# Altium schematic sheet Drive_A2V3.SchDoc
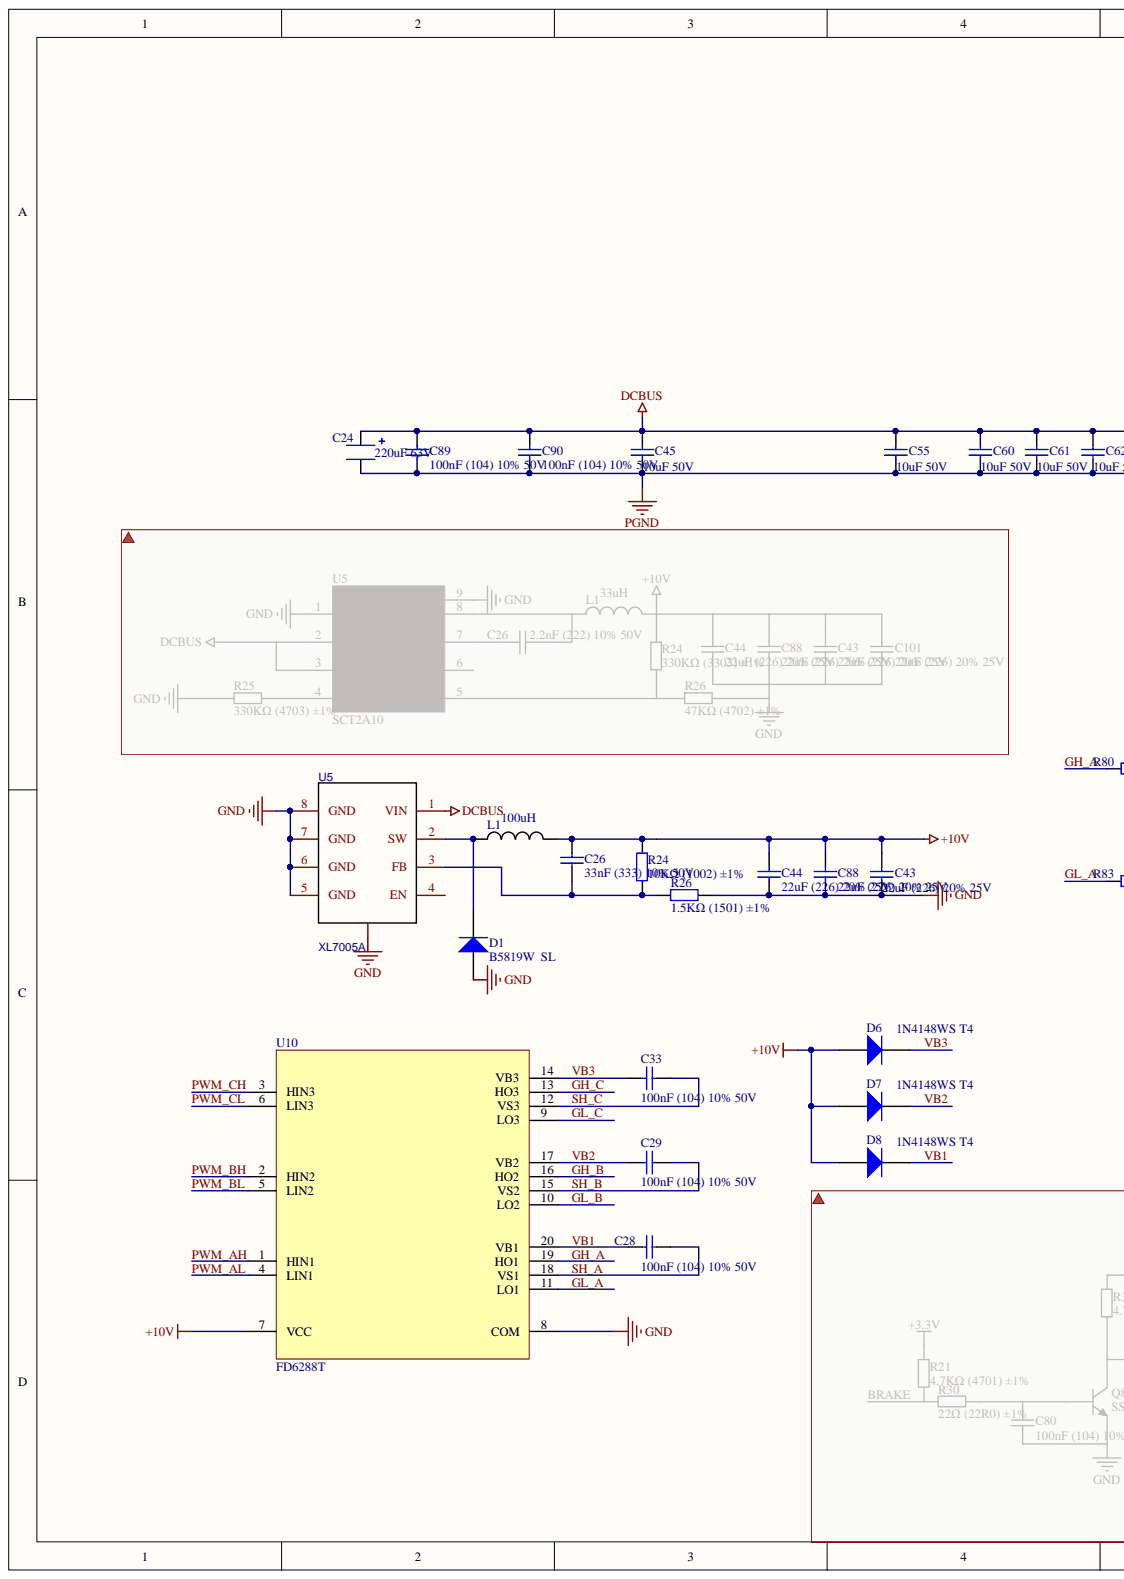
[diagram p0: Drive_A2V3.SchDoc, left]
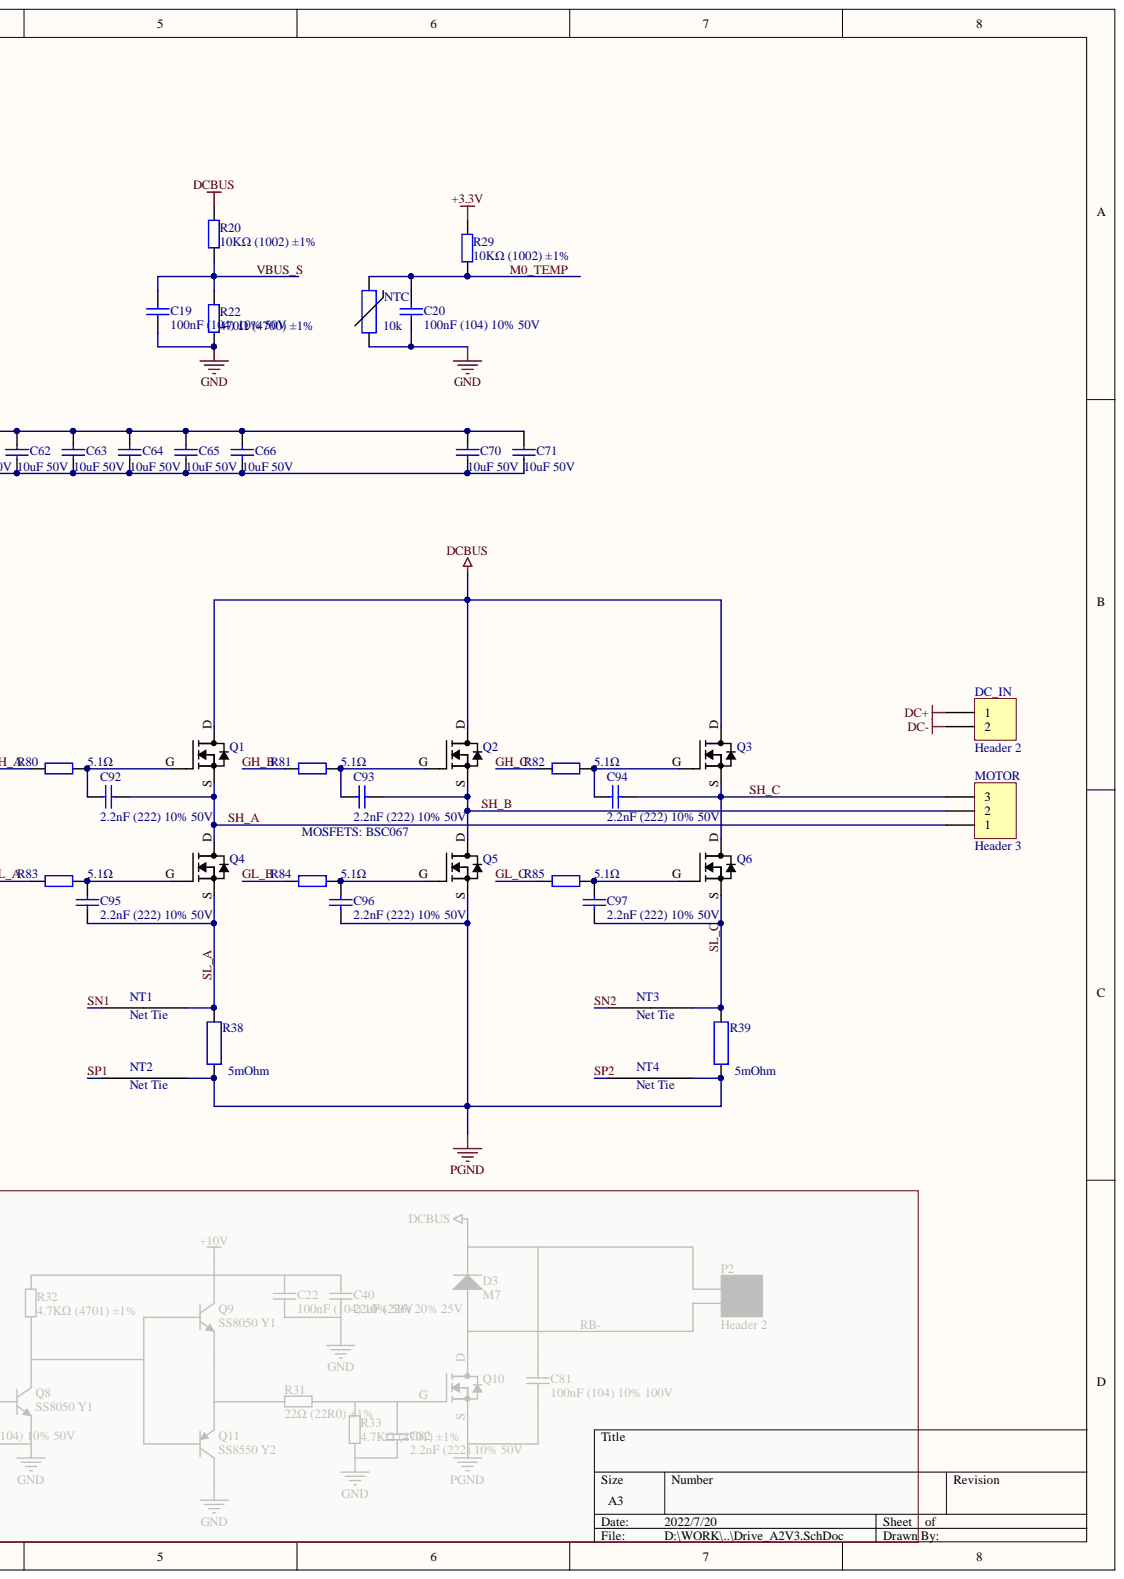
[diagram p1: Drive_A2V3.SchDoc, right]

## Parts
C19  100nF (104) 10% 50V  [0603_C]
C20  100nF (104) 10% 50V  [0603_C]
C24  value 220uF 63V  [10*20 CC]  (hidden comment: cap)
C26  33nF (333) 10% 50V  [0603_C]
C28  100nF (104) 10% 50V  [0603_C]
C29  100nF (104) 10% 50V  [0603_C]
C33  100nF (104) 10% 50V  [0603_C]
C43  22uF (226) 20% 25V  [0805_C]
C44  22uF (226) 20% 25V  [0805_C]
C45  10uF 50V  [1206_C]
C55  10uF 50V  [1206_C]
C60  10uF 50V  [1206_C]
C61  10uF 50V  [1206_C]
C62  10uF 50V  [1206_C]
C63  10uF 50V  [1206_C]
C64  10uF 50V  [1206_C]
C65  10uF 50V  [1206_C]
C66  10uF 50V  [1206_C]
C70  10uF 50V  [1206_C]
C71  10uF 50V  [1206_C]
C88  22uF (226) 20% 25V  [0805_C]
C89  100nF (104) 10% 50V  [0603_C]
C90  100nF (104) 10% 50V  [0603_C]
C92  2.2nF (222) 10% 50V  [0603_C]
C93  2.2nF (222) 10% 50V  [0603_C]
C94  2.2nF (222) 10% 50V  [0603_C]
C95  2.2nF (222) 10% 50V  [0603_C]
C96  2.2nF (222) 10% 50V  [0603_C]
C97  2.2nF (222) 10% 50V  [0603_C]
D1  B5819W  SL  [SOD-123]
D6  1N4148WS T4  [SOD-323]
D7  1N4148WS T4  [SOD-323]
D8  1N4148WS T4  [SOD-323]
DC_IN  Header 2  [XT30PW-M30-2P]
L1  100uH  [NR4020]
MOTOR  Header 3  [MR30PW-M-3P]
NT1  0.25mm  [NetTie_0.25mm]  (value not drawn)
NT2  0.25mm  [NetTie_0.25mm]  (value not drawn)
NT3  0.25mm  [NetTie_0.25mm]  (value not drawn)
NT4  0.25mm  [NetTie_0.25mm]  (value not drawn)
NTC  10k  [0603_R]
Q1  (no value)  [PG-TDSON-8]
Q2  (no value)  [PG-TDSON-8]
Q3  (no value)  [PG-TDSON-8]
Q4  (no value)  [PG-TDSON-8]
Q5  (no value)  [PG-TDSON-8]
Q6  (no value)  [PG-TDSON-8]
R20  10KΩ (1002) ±1%  [0603_R]
R22  470Ω (4700) ±1%  [0603_R]
R24  10KΩ (1002) ±1%  [0603_R]
R26  1.5KΩ (1501) ±1%  [0603_R]
R29  10KΩ (1002) ±1%  [0603_R]
R38  value 5mOhm  [RESC6432X09N]  (hidden comment: 500uOhm)
R39  value 5mOhm  [RESC6432X09N]  (hidden comment: 500uOhm)
R80  5.1Ω  [0603_R]
R81  5.1Ω  [0603_R]
R82  5.1Ω  [0603_R]
R83  5.1Ω  [0603_R]
R84  5.1Ω  [0603_R]
R85  5.1Ω  [0603_R]
U5  XL7005A  [LM5164]
U10  FD6288T  [TSSOP20_N]

## Nets
+3.3V: R29.2
+10V: C26.2 C43.2 C44.1 C88.2 D6.1 D7.1 D8.1 L1.2 R24.1 U10.7(VCC)
DC+: DC_IN.1
DC-: DC_IN.2
DCBUS: C24.1 C45.2 C55.2 C60.2 C61.2 C62.2 C63.2 C64.2 C65.2 C66.2 C70.2 C71.2 C89.2 C90.2 Q1.D Q2.D Q3.D R20.2 U5.1(VIN)
GND: C19.1 C20.1 C43.1 C44.2 C88.1 D1.1 NTC.1 R22.1 R26.2 U5.5(GND) U5.6(GND) U5.7(GND) U5.8(GND) U5.9 U10.8(COM)
PGND: C24.2 C45.1 C55.1 C60.1 C61.1 C62.1 C63.1 C64.1 C65.1 C66.1 C70.1 C71.1 C89.1 C90.1 C96.1 NT2.2 NT4.2 Q5.S R38.1 R39.1
GH_A: R80.1 U10.19(HO1)
GH_B: R81.1 U10.16(HO2)
GH_C: R82.1 U10.13(HO3)
GL_A: R83.1 U10.11(LO1)
GL_B: R84.1 U10.10(LO2)
GL_C: R85.1 U10.9(LO3)
M0_TEMP: C20.2 NTC.2 R29.1
PWM_AH: U10.1(HIN1)
PWM_AL: U10.4(LIN1)
PWM_BH: U10.2(HIN2)
PWM_BL: U10.5(LIN2)
PWM_CH: U10.3(HIN3)
PWM_CL: U10.6(LIN3)
SH_A: C28.1 C92.1 MOTOR.1 Q1.S Q4.D U10.18(VS1)
SH_B: C29.1 C93.1 MOTOR.2 Q2.S Q5.D U10.15(VS2)
SH_C: C33.1 C94.1 MOTOR.3 Q3.S Q6.D U10.12(VS3)
SL_A: C95.1 NT1.2 Q4.S R38.2
SL_C: C97.1 NT3.2 Q6.S R39.2
SN1: NT1.1
SN2: NT3.1
SP1: NT2.1
SP2: NT4.1
VB1: C28.2 D8.2 U10.20(VB1)
VB2: C29.2 D7.2 U10.17(VB2)
VB3: C33.2 D6.2 U10.14(VB3)
VBUS_S: C19.2 R20.1 R22.2
NetC26_1: C26.1 R24.2 R26.1 U5.3(FB)
NetC92_2: C92.2 Q1.G R80.2
NetC93_2: C93.2 Q2.G R81.2
NetC94_2: C94.2 Q3.G R82.2
NetC95_2: C95.2 Q4.G R83.2
NetC96_2: C96.2 Q5.G R84.2
NetC97_2: C97.2 Q6.G R85.2
NetD1_2: D1.2 L1.1 U5.2(SW)

Not connected: U5 4(EN)

## Library data of the parts
C19 C20 C28 C29 C33 C89 C90 = 0603 100nF (104) 10% 50V: 贴片电容; Component Kind: Standard; ComponentLink1Description: 供应商链接; ComponentLink1URL: http://www.szlcsc.com/product/details_15331.html; Library Name: Cap.SchLib; Package: 0603; Supplier: LC; SuppliersPartNumber: C14663
C24 = T495C686M016ATE250: CAP TANT 68UF 16V 20% 2312 250mOhm; Manufacturer: KEMET; Manufacturer Size Code: C; Lifetime @ Temp.: 2000 Hrs @ 85°C; Type: Molded; Value: 220uF 63V; Category: Capacitors; Component Kind: Standard; ComponentLink1Description: https://search.kemet.com/component-edge/download/datasheet/T495C686M016ATE250.pdf; ComponentLink1URL: https://search.kemet.com/component-edge/download/datasheet/T495C686M016ATE250.pdf; ESR (Equivalent Series Resistance): 250 mOhm; Failure Rate: -; Features: General Purpose; Height - Seated (Max): 0.110" (2.80mm); Lead Spacing: -; Library Name: CustomComponents.SchLib; Manufacturer Part Number: T495C686M016ATE250; Mounting Type: Surface Mount; Operating Temperature: -55°C ~ 125°C; Package / Case: 2312 (6032 Metric); Packaging: Cut Tape (CT); Part Status: Active; Pricing 1: 1=0.98, 10=0.747, 100=0.5345 (USD); Pricing 2: 500=0.35986, 1000=0.30988, 2500=0.28988, 5000=0.27608 (USD); RoHS: RoHS Compliant; Size / Dimension: 0.236" L x 0.126" W (6.00mm x 3.20mm); Stock: 39507; Supplier 1: Digi-Key; Supplier 2: Digi-Key; Supplier Part Number 1: 399-10452-1-ND; Supplier Part Number 2: 399-10452-2-ND; Tolerance: ±20%
C26 = 0603 10nF (103) 10% 50V: 贴片电容; Component Kind: Standard; ComponentLink1Description: 供应商链接; ComponentLink1URL: http://www.szlcsc.com/product/details_58143.html; Library Name: Miscellaneous Devices LC.IntLib; Package: 0603; Supplier: LC; SuppliersPartNumber: C57112
C43 C44 C88 = 0805 22uF (226) 20% 25V: 贴片电容; Component Kind: Standard; ComponentLink1Description: 供应商链接; ComponentLink1URL: http://www.szlcsc.com/product/details_46786.html; Library Name: Cap.SchLib; Package: 0805; Supplier: LC; SuppliersPartNumber: C45783
C45 C55 C60-C66 C70 C71 = 1206 10uF (106) 10% 50V: 贴片电容; Component Kind: Standard; ComponentLink1Description: 供应商链接; ComponentLink1URL: http://www.szlcsc.com/product/details_14236.html; Library Name: Cap.SchLib; Package: 1206; Supplier: LC; SuppliersPartNumber: C13585
C92-C97 = 0603 2.2nF (222) 10% 50V: 贴片电容; Component Kind: Standard; ComponentLink1Description: 供应商链接; ComponentLink1URL: http://www.szlcsc.com/product/details_1956.html; Library Name: Cap.SchLib; Package: 0603; Supplier: LC; SuppliersPartNumber: C1604
D1 = SOD-123 B5819W  SL: 肖特基二极管; Component Kind: Standard; ComponentLink1Description: 供应商链接; ComponentLink1URL: http://www.szlcsc.com/product/details_9093.html; Library Name: Miscellaneous Devices LC.IntLib; Package: SOD-123; Supplier: LC; SuppliersPartNumber: C8598
D6-D8 = SOD-323 1N4148WS T4: 二极管; Component Kind: Standard; ComponentLink1Description: 供应商链接; ComponentLink1URL: http://www.szlcsc.com/product/details_2485.html; Library Name: Diode.SchLib; Package: SOD-323; Supplier: LC; SuppliersPartNumber: C2128
DC_IN = Header 2: Header, 2-Pin; Component Kind: Standard; LatestRevisionDate: 17-Jul-2002; LatestRevisionNote: Re-released for DXP Platform.; Library Name: Miscellaneous Connectors.IntLib; Signal Integrity: Connector; Publisher: Altium Limited
L1 = NR6028T220M: INDUCTOR 100UH 0.4A 175.5 MOHM; Component Kind: Standard; Library Name: CustomComponents.SchLib; Value: 100uH
MOTOR = Header 3: Header, 3-Pin; Component Kind: Standard; LatestRevisionDate: 17-Jul-2002; LatestRevisionNote: Re-released for DXP Platform.; Library Name: Miscellaneous Connectors.IntLib; Signal Integrity: Connector; Publisher: Altium Limited
NT1-NT4 = NetTie_0.25mm: Component Kind: Net Tie; Library Name: CustomComponents.SchLib
NTC = NCP15XH103F03RC: THERMISTOR 10K OHM NTC 0402 SMD; Component Kind: Standard; Library Name: CustomComponents.SchLib; Value: 10k
Q1-Q6 = NTMFS4935NT1G: BSC067; Manufacturer: ON Semiconductor; Current - Continuous Drain (Id) @ 25°C: 13A (Ta), 93A (Tc); Drain to Source Voltage (Vdss): 30V; Drive Voltage (Max Rds On,  Min Rds On): 4.5V, 10V; FET Type: N-Channel; Gate Charge (Qg) (Max) @ Vgs: 49.4nC @ 10V; Input Capacitance (Ciss) (Max) @ Vds: 4850pF @ 15V; Power Dissipation (Max): 930mW (Ta), 48W (Tc); Rds On (Max) @ Id, Vgs: 3.2 mOhm @ 30A, 10V; Vgs (Max): ±20V; Vgs(th) (Max) @ Id: 2.2V @ 250µA; Category: Discrete Semiconductor Products; Component Kind: Standard; ComponentLink1Description: http://www.onsemi.com/pub_link/Collateral/NTMFS4935N-D.PDF; ComponentLink1URL: http://www.onsemi.com/pub_link/Collateral/NTMFS4935N-D.PDF; FET Feature: -; Library Name: CustomComponents.SchLib; Manufacturer Part Number: NTMFS4935NT1G; Mounting Type: Surface Mount; Operating Temperature: -55°C ~ 150°C (TJ); Package / Case: 8-PowerTDFN, 5 Leads; Packaging: Cut Tape (CT); Part Status: Active; Pricing 1: 1=0.61, 10=0.541, 100=0.4145, 500=0.3277 (USD); RoHS: RoHS Compliant; Stock 1: 932; Supplier 1: Digi-Key; Supplier Device Package: 5-DFN (5x6) (8-SOFL); Supplier Part Number 1: NTMFS4935NT1GOSCT-ND; Technology: MOSFET (Metal Oxide)
R20 R24 R29 = 0603 10KΩ (1002) 1%: 贴片电阻; Component Kind: Standard; ComponentLink1Description: 供应商链接; ComponentLink1URL: http://www.szlcsc.com/product/details_26547.html; Library Name: RES.SchLib; Package: 0603; Supplier: LC; SuppliersPartNumber: C25804
R22 = 0603 470Ω (4700) 1%: 贴片电阻; Component Kind: Standard; ComponentLink1Description: 供应商链接; ComponentLink1URL: http://www.szlcsc.com/product/details_23906.html; Library Name: RES.SchLib; Package: 0603; Supplier: LC; SuppliersPartNumber: C23179
R26 = 0603 1.5KΩ (1501) 1%: 贴片电阻; Component Kind: Standard; ComponentLink1Description: 供应商链接; ComponentLink1URL: http://www.szlcsc.com/product/details_23570.html; Library Name: Miscellaneous Devices LC.IntLib; Package: 0603; Supplier: LC; SuppliersPartNumber: C22843
R38 R39 = CSR2512C0R0005F: RES SMD 0.0005 OHM 1% 3W 2512; Manufacturer: Riedon; Temperature Coefficient: ±100ppm/°C; Category: Resistors; Component Kind: Standard; ComponentLink1Description: http://riedon.com/media/pdf/CSR.pdf; ComponentLink1URL: http://riedon.com/media/pdf/CSR.pdf; Composition: Metal Element; Failure Rate: -; Features: Current Sense; Height - Seated (Max): 0.057" (1.45mm); Library Name: CustomComponents.SchLib; Manufacturer Part Number: CSR2512C0R0005F; Operating Temperature: -55°C ~ 170°C; Package / Case: 2512 (6432 Metric); Packaging: Tape & Reel (TR); Part Status: Active; Power (Watts): 3W; Pricing 1: 2000=0.19817, 6000=0.1937, 10000=0.187, 50000=0.18148 (USD); Pricing 2: 1=0.69, 10=0.574, 25=0.4916, 50=0.4426, 100=0.3852, 250=0.3442, 500=0.27864, 1000=0.22536 (USD); RoHS: RoHS Compliant; Size / Dimension: 0.250" L x 0.118" W (6.35mm x 3.00mm); Stock: 2000; Supplier 1: Digi-Key; Supplier 2: Digi-Key; Supplier Device Package: 2512; Supplier Part Number 1: 696-1183-2-ND; Supplier Part Number 2: 696-1183-1-ND; Tolerance: ±1%
R80-R85 = 0603 5.1Ω (5R10) 1%: 贴片电阻; Component Kind: Standard; ComponentLink1Description: 供应商链接; ComponentLink1URL: http://www.szlcsc.com/product/details_25940.html; Library Name: RES.SchLib; Package: 0603; Supplier: LC; SuppliersPartNumber: C25197
U5 = XL7005A: BOM_Manufacturer: XLSEMI; BOM_JLCPCB Part Class: Extended Part; BOM_Manufacturer Part: XL7005A; BOM_Supplier: LCSC; BOM_Supplier Part: C50848; Component Kind: Standard; spicePre: U; spiceSymbolName: XL7005A
U10 = FD6288T: Component Kind: Standard; Library Name: MYLIB.SchLib
